# Altium schematic sheet 01_NRF52.SchDoc(01_NRF52)
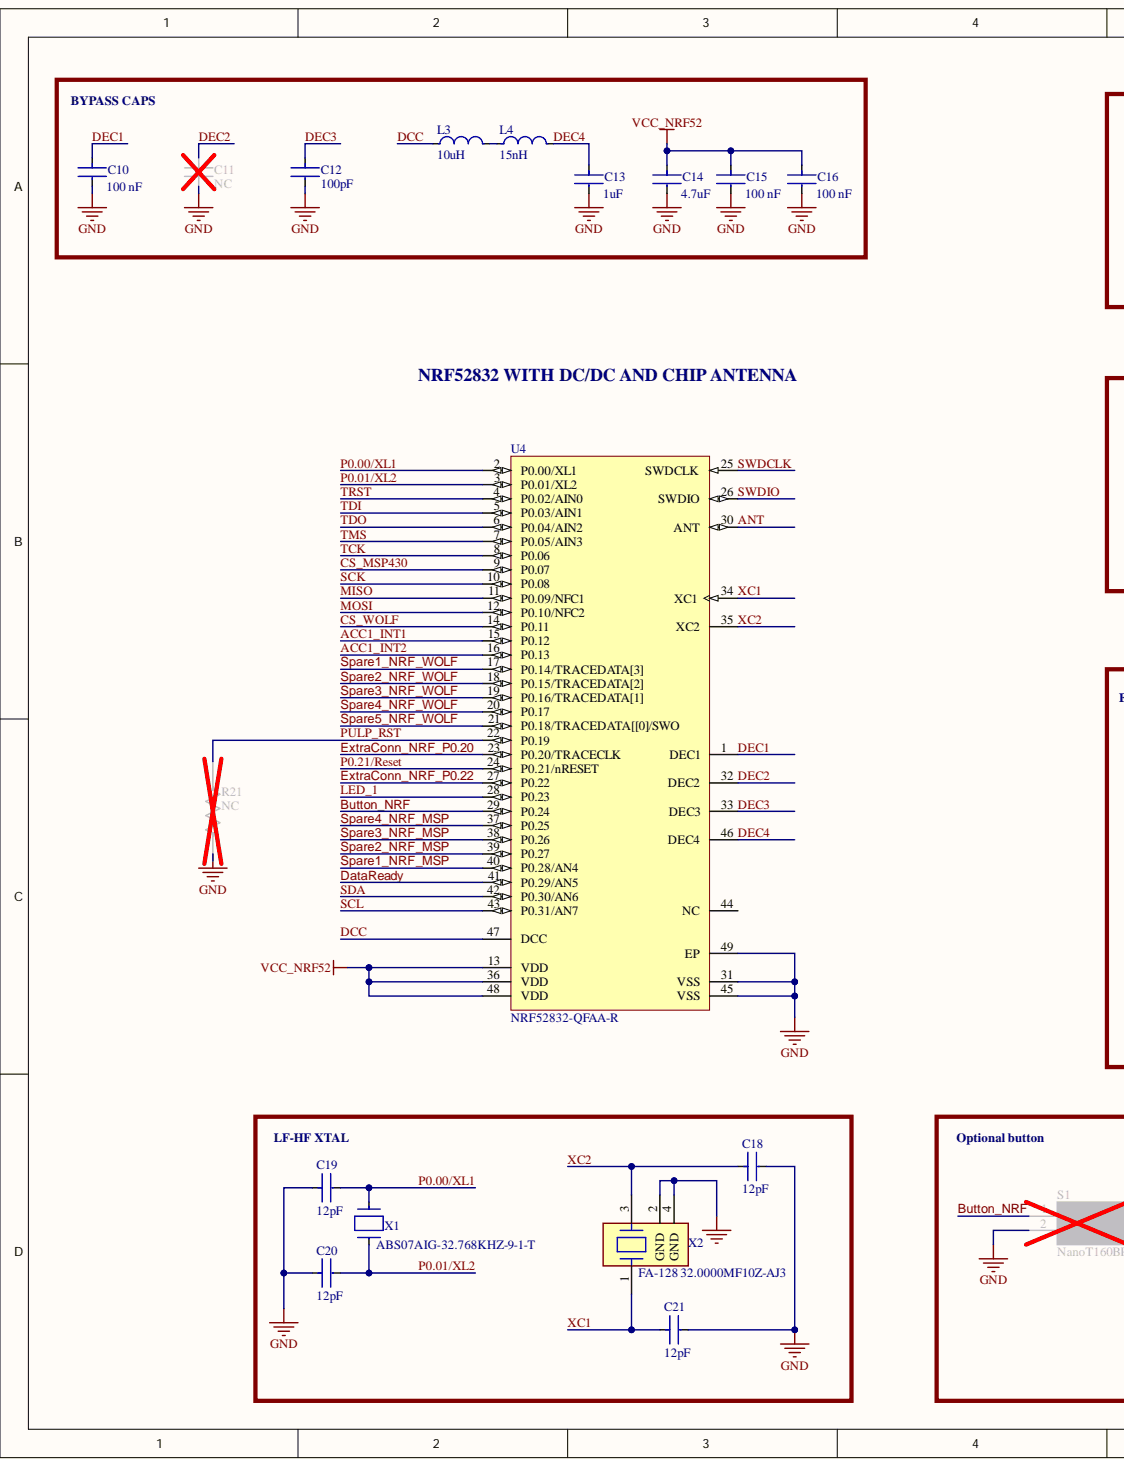
[diagram p0: 01_NRF52.SchDoc, left]
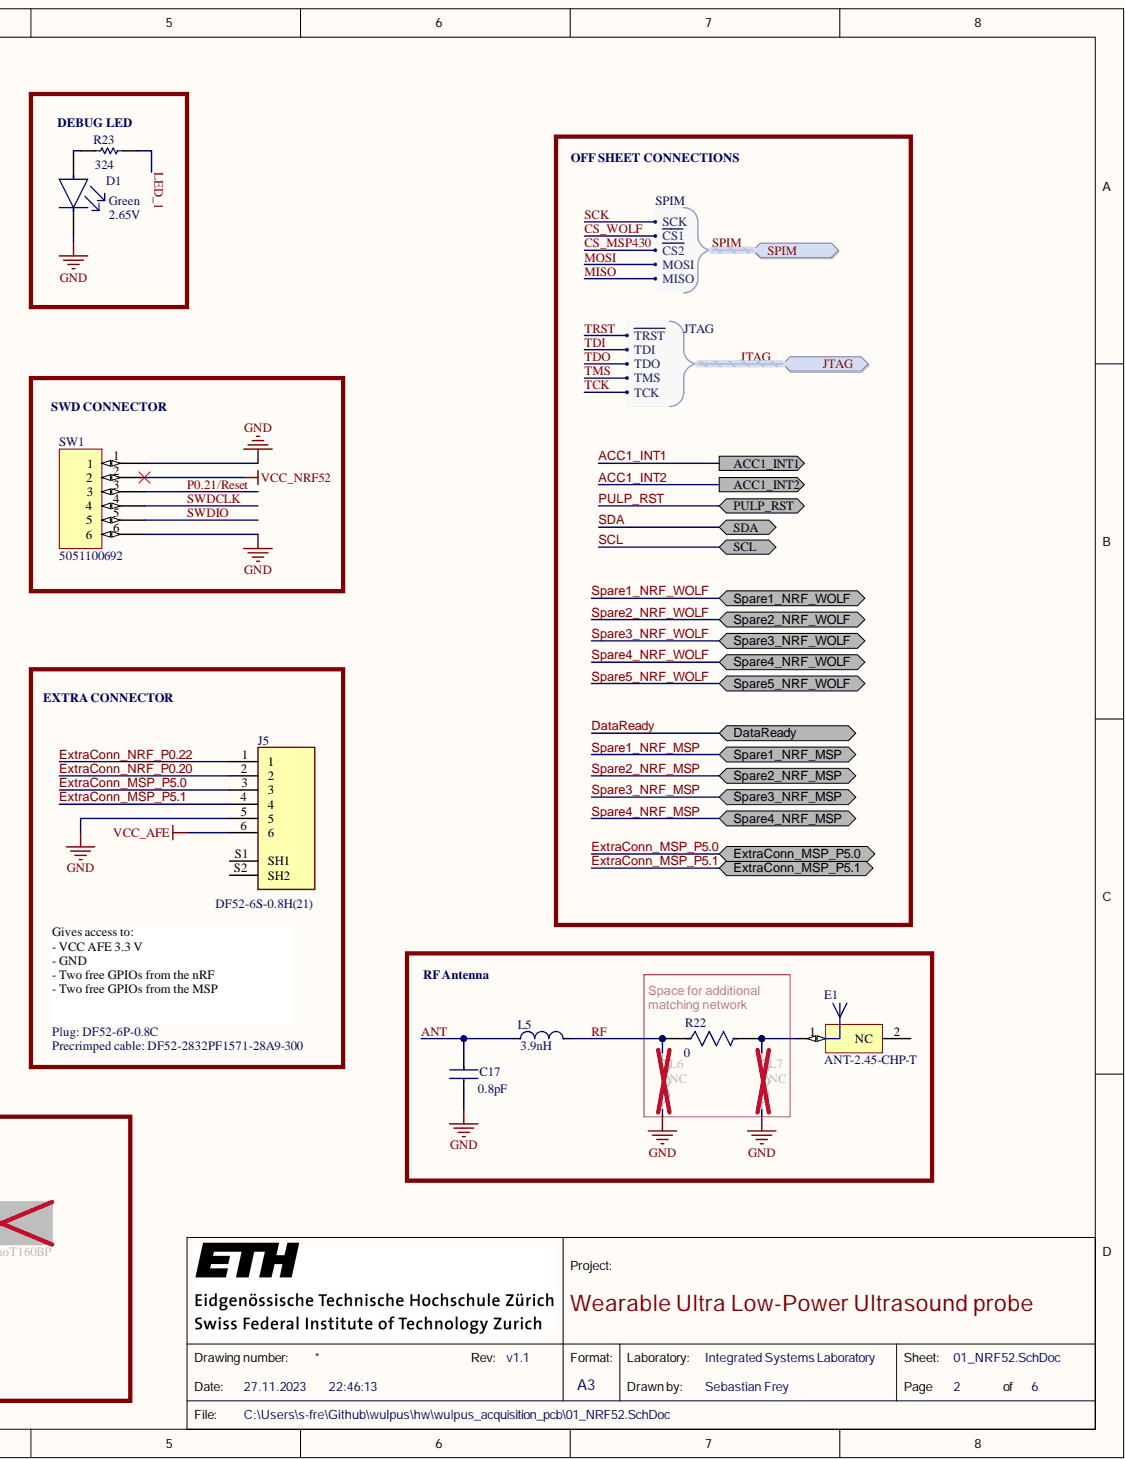
[diagram p1: 01_NRF52.SchDoc, right]

## Parts
C10  value 100 nF  Capacitance: 100 nF  [FP-CC0402-MFG]  (hidden comment: CC0402KRX7R7BB104)
C11  Capacitance: NC  [FP-04025A101JAT2A-MFG]  (hidden comment: not mounted)
C12  Capacitance: 100pF  [FP-04025A101JAT2A-MFG]  (hidden comment: 04025A101JAT2A)
C13  Capacitance: 1uF  [FP-C1608-080-0_1-IPC_B]  (hidden comment: C1608X7R1C105K080AC)
C14  Capacitance: 4.7uF  [FP-0603-L_1_6_0_15-W_0_8-IPC_A]  (hidden comment: EMK107ABJ475KA-T)
C15  value 100 nF  [FP-CC0402-MFG]  (hidden comment: CC0402KRX7R7BB104)
C16  value 100 nF  Capacitance: 100 nF  [FP-CC0402-MFG]  (hidden comment: CC0402KRX7R7BB104)
C17  Capacitance: 0.8pF  [FP-GJM155-0_05-IPC_A]  (hidden comment: GJM1555C1HR80WB01D)
C18  Capacitance: 12pF  [FP-GJM155-0_05-IPC_A]  (hidden comment: GJM1555C1H120GB01D)
C19  Capacitance: 12pF  [FP-GJM155-0_05-IPC_A]  (hidden comment: GJM1555C1H120GB01D)
C20  Capacitance: 12pF  [FP-GJM155-0_05-IPC_A]  (hidden comment: GJM1555C1H120GB01D)
C21  Capacitance: 12pF  [FP-GJM155-0_05-IPC_A]  (hidden comment: GJM1555C1H120GB01D)
D1  Color: Green  Forward Voltage: 2.65V  [FP-APHD1608LZGCK-MFG]  (hidden comment: APHD1608LZGCK)
E1  ANT-2.45-CHP-T  [ANT-2.4-CHP]
J5  DF52-6S-0.8H(21)  [HIROSE_DF52-6S-0.8H(21)]
L3  Inductance: 10uH  [L0603B100KDWFT]  (hidden comment: L0603B100KDWFT)
L4  Inductance: 15nH  [FP-LQW15AN_00-W0_6-0_1-MFG]  (hidden comment: LQW15AN15NJ00D)
L5  Inductance: 3.9nH  [INDC1005X45N]  (hidden comment: LQP15MN3N9B02D)
L6  Inductance: NC  [INDC1005X45N]
L7  Inductance: NC  [INDC1005X45N]
R21  Resistance: NC  [FP-ERJ2RK-IPC_C]
R22  CR0402-J/-000GLF  [FP-CR0402-IPC_B]  (value not drawn)
R23  CPF0402B324RE1  [RESC1005X35X20ML05T10]  (value not drawn)
S1  NanoT160BP  [NanoT160BP]
SW1  5051100692  [MOLEX_5051100692]
U4  NRF52832-QFAA-R  [NRSC-QF-48_M]
X1  ABS07AIG-32_768KHZ-9-1-T  Part Nr: ABS07AIG-32.768KHZ-9-1-T  [Crys_Abracon_ABS07AIG-32_768KHZ-9-1-T_eec_MFG]
X2  FA-128 32.0000MF10Z-AJ3  [Crys_Seiko_Epson_Corporation_FA-128_32.0000MF10Z-AJ3_eec_0]

## Ports
ACC1_INT1, ACC1_INT2, DataReady, ExtraConn_MSP_P5.0, ExtraConn_MSP_P5.1, JTAG, JTAG.TCK, JTAG.TDI, JTAG.TDO, JTAG.TMS, JTAG.~{TRST}, PULP_RST, SCL, SDA, Spare1_NRF_MSP, Spare1_NRF_WOLF, Spare2_NRF_MSP, Spare2_NRF_WOLF, Spare3_NRF_MSP, Spare3_NRF_WOLF, Spare4_NRF_MSP, Spare4_NRF_WOLF, Spare5_NRF_WOLF, SPIM, SPIM.MISO, SPIM.MOSI, SPIM.SCK, SPIM.~{CS1}, SPIM.~{CS2}

## Nets
GND: C10.2 C11.1 C12.1 C13.1 C14.2 C15.2 C16.2 C17.2 C18.2 C19.1 C20.1 C21.2 D1.1 J5.5 L6.2 L7.2 R21.2 S1.2 SW1.1 SW1.6 U4.31(VSS) U4.45(VSS) U4.49(EP) X2.2(GND) X2.4(GND)
VCC_AFE: J5.6
VCC_NRF52: C14.1 C15.1 C16.1 SW1.2 U4.13(VDD) U4.36(VDD) U4.48(VDD)
ACC1_INT1: U4.15(P0.12) ; port ACC1_INT1
ACC1_INT2: U4.16(P0.13) ; port ACC1_INT2
ANT: C17.1 L5.1 U4.30(ANT)
Button_NRF: S1.1 U4.29(P0.24)
CS_MSP430: U4.9(P0.07) ; port SPIM
CS_WOLF: U4.14(P0.11) ; port SPIM
DataReady: U4.41(P0.29/AN5) ; port DataReady
DCC: L3.1 U4.47(DCC)
DEC1: C10.1 U4.1(DEC1)
DEC2: C11.2 U4.32(DEC2)
DEC3: C12.2 U4.33(DEC3)
DEC4: C13.2 L4.2 U4.46(DEC4)
ExtraConn_MSP_P5.0: J5.3 ; port ExtraConn_MSP_P5.0
ExtraConn_MSP_P5.1: J5.4 ; port ExtraConn_MSP_P5.1
ExtraConn_NRF_P0.20: J5.2 U4.23(P0.20/TRACECLK)
ExtraConn_NRF_P0.22: J5.1 U4.27(P0.22)
JTAG.~{TRST}: U4.4(P0.02/AIN0) ; port JTAG
JTAG.TCK: U4.8(P0.06) ; port JTAG
JTAG.TDI: U4.5(P0.03/AIN1) ; port JTAG
JTAG.TDO: U4.6(P0.04/AIN2) ; port JTAG
JTAG.TMS: U4.7(P0.05/AIN3) ; port JTAG
LED_1: R23.1 U4.28(P0.23)
MISO: U4.11(P0.09/NFC1) ; port SPIM
MOSI: U4.12(P0.10/NFC2) ; port SPIM
P0.00/XL1: C19.2 U4.2(P0.00/XL1) X1.1
P0.01/XL2: C20.2 U4.3(P0.01/XL2) X1.2
P0.21/Reset: SW1.3 U4.24(P0.21/nRESET)
PULP_RST: R21.1 U4.22(P0.19) ; port PULP_RST
RF: L5.2 L6.1 R22.1
SCK: U4.10(P0.08) ; port SPIM
SCL: U4.43(P0.31/AN7) ; port SCL
SDA: U4.42(P0.30/AN6) ; port SDA
Spare1_NRF_MSP: U4.40(P0.28/AN4) ; port Spare1_NRF_MSP
Spare1_NRF_WOLF: U4.17(P0.14/TRACEDATA[3]) ; port Spare1_NRF_WOLF
Spare2_NRF_MSP: U4.39(P0.27) ; port Spare2_NRF_MSP
Spare2_NRF_WOLF: U4.18(P0.15/TRACEDATA[2]) ; port Spare2_NRF_WOLF
Spare3_NRF_MSP: U4.38(P0.26) ; port Spare3_NRF_MSP
Spare3_NRF_WOLF: U4.19(P0.16/TRACEDATA[1]) ; port Spare3_NRF_WOLF
Spare4_NRF_MSP: U4.37(P0.25) ; port Spare4_NRF_MSP
Spare4_NRF_WOLF: U4.20(P0.17) ; port Spare4_NRF_WOLF
Spare5_NRF_WOLF: U4.21(P0.18/TRACEDATA[[0]/SWO) ; port Spare5_NRF_WOLF
SWDCLK: SW1.4 U4.25(SWDCLK)
SWDIO: SW1.5 U4.26(SWDIO)
XC1: C21.1 U4.34(XC1) X2.1
XC2: C18.1 U4.35(XC2) X2.3
NetD1_2: D1.2 R23.2
NetE1_1: E1.1 L7.1 R22.2
NetL3_2: L3.2 L4.1

Not connected: E1 2; J5 S1(SH1) S2(SH2); U4 44(NC)

## Library data of the parts
C10 C16 = CMP-03422-000048-1: CAP CER 0.1UF 16V X7R 0402; Manufacturer: YAGEO; Automotive Grade: NA; Material: Ceramic; Temperature Coefficient: 30ppm/°C; Tolerance: 0.1; Automotive: No; Category: Cap; Component Kind: Standard; ComponentLink2Description: Datasheet; ComponentLink2URL: http://www.yageo.com/documents/recent/UPY-GP_NP0_16V-to-50V_16.pdf; Device Class L1: Passive Components; Device Class L2: Capacitors; Device Class L3: Ceramic Capacitors; Digikey Description: CAP CER 0.1UF 16V X7R 0402; Footprint Url: http://www.yageo.com/exep/pages/download/literatures/UPY-C_GEN_24.pdf; Height: 0.55mm; Ipc Land Pattern Name: CAPC10050X50; Lead Free: Yes; Library Name: Altium Content Vault; Manufacturer Part Number: CC0402KRX7R7BB104; Mouser Description: 0402 0.1 uF 16 V ±10 % Tolerance X7R SMT Multilayer Ceramic Capacitor; Octopart: https://octopart.com/cc0402krx7r7bb104-yageo-42244624; Package: CC0402; RoHS: TRUE; Temperature: -55°C to +125°C; Temperature Characteristic: X7R; Temperature Range High: +125°C; Temperature Range Low: -55°C; Voltage Rating: 16 V
C11 = CMP-2008-02568-2: Dielectric: C0G; Dissipation Factor: 2.5%; Insulation Resistance: 1GR; Temperature Coefficient: 30ppm/°C; Tolerance: 5%; Voltage: 50V; Case Code (Imperial): 0402; Case Code (Metric): 1005; Case/Package: 0402; Component Kind: Standard; Construction: Flat; Contact Plating: Tin; Depth: 0.5mm; Description: not mounted; Height: 0.56mm; Lead Free: Lead Free; Length: 1mm; Library Name: Altium Content Vault; Max Operating Temperature: 125°C; Min Operating Temperature: -55°C; Mount: Surface Mount; Package Quantity: 1; Packaging: Tape and Reel; Radiation Hardening: No; REACH SVHC: No SVHC; RoHS Compliant: Yes; Series: -; Termination: SMD/SMT; Thickness: 0.022inch; Voltage Rating: 50V; Voltage Rating (DC): 50V; Width: 0.02inch
C12 = CMP-2008-02568-2: CAP CER 100PF 50V C0G/NP0 0402; Dielectric: C0G; Dissipation Factor: 2.5%; Insulation Resistance: 1GR; Temperature Coefficient: 30ppm/°C; Tolerance: 5%; Case Code (Imperial): 0402; Case Code (Metric): 1005; Case/Package: 0402; Component Kind: Standard; Construction: Flat; Contact Plating: Tin; Depth: 0.5mm; Height: 0.56mm; Lead Free: Lead Free; Length: 1mm; Library Name: Altium Content Vault; Max Operating Temperature: 125°C; Min Operating Temperature: -55°C; Mount: Surface Mount; Package Quantity: 1; Packaging: Tape and Reel; Radiation Hardening: No; REACH SVHC: No SVHC; RoHS Compliant: Yes; Series: -; Termination: SMD/SMT; Thickness: 0.022inch; Voltage: 50V; Voltage Rating: 50V; Voltage Rating (DC): 50V; Width: 0.02inch
C13 = CMP-08246-002727-1: Multilayer Ceramic Capacitors 1μF ±10% 16V X7R SMD 0603; Case Code (Imperial): 0603; Case Code (Metric): 1608; Case/Package: 0603; Component Kind: Standard; Construction: Flat; Depth: 0.8mm; Dielectric: X7R; Dielectric Material: Ceramic; Height: 0.8mm; Lead Free: Lead Free; Length: 1.6mm; Library Name: Altium Content Vault; Max Operating Temperature: 125°C; Min Operating Temperature: -55°C; Mount: Surface Mount; Package Quantity: 1; Packaging: Tape and Reel; Radiation Hardening: No; REACH SVHC: No SVHC; Resistor Type: Ceramic; RoHS Compliant: Yes; Series: C; Termination: SMD/SMT; Thickness: 0.037inch; Tolerance: 10%; Voltage: 16V; Voltage Rating: 16V; Voltage Rating (DC): 16V; Weight: 7.1E-05oz; Width: 0.031inch
C14 = CMP-2000-06225-3: None; Dielectric: X5R; Tolerance: 10%; Voltage Rating: 16V; Case Code (Imperial): 0603; Case Code (Metric): 1608; Case/Package: 0603; Component Kind: Standard; Construction: Flat; Height: 0.8mm; Length: 1.6mm; Library Name: Altium Content Vault; Max Operating Temperature: 85°C; Min Operating Temperature: -55°C; Mount: Surface Mount; Number of Pins: 2; Package Quantity: 1; Packaging: Tape and Reel; REACH SVHC: No SVHC; Resistor Type: Ceramic; RoHS Compliant: Yes; Series: M; Simulation: EMK107ABJ475_A-T; Termination: SMD/SMT; Thickness: 0.035inch; Voltage Rating (DC): 16V; Width: 0.8mm
C15 = CMP-03422-000048-1: CAP CER 0.1UF 16V X7R 0402; Manufacturer: YAGEO; Automotive Grade: NA; Capacitance: 100 nF; Material: Ceramic; Temperature Coefficient: 30ppm/°C; Tolerance: 0.1; Value: 100 nF; Automotive: No; Category: Cap; Component Kind: Standard; ComponentLink2Description: Datasheet; ComponentLink2URL: http://www.yageo.com/documents/recent/UPY-GP_NP0_16V-to-50V_16.pdf; Device Class L1: Passive Components; Device Class L2: Capacitors; Device Class L3: Ceramic Capacitors; Digikey Description: CAP CER 0.1UF 16V X7R 0402; Footprint Url: http://www.yageo.com/exep/pages/download/literatures/UPY-C_GEN_24.pdf; Height: 0.55mm; Ipc Land Pattern Name: CAPC10050X50; Lead Free: Yes; Library Name: Altium Content Vault; Manufacturer Part Number: CC0402KRX7R7BB104; Mouser Description: 0402 0.1 uF 16 V ±10 % Tolerance X7R SMT Multilayer Ceramic Capacitor; Octopart: https://octopart.com/cc0402krx7r7bb104-yageo-42244624; Package: CC0402; RoHS: TRUE; Temperature: -55°C to +125°C; Temperature Characteristic: X7R; Temperature Range High: +125°C; Temperature Range Low: -55°C; Voltage Rating: 16 V
C17 = CMP-2008-03761-2: Multi-Layer Ceramic Capacitor 0.8pF C0G  ±0.05pF 0402 Paper T/R; Voltage Rating: 50V; Case Code (Imperial): 0402; Case Code (Metric): 1005; Case/Package: 0402; Component Kind: Standard; Depth: 0.5mm; Dielectric: C0G; Height: 0.5mm; Length: 1mm; Library Name: Altium Content Vault; Max Operating Temperature: 125°C; Min Operating Temperature: -55°C; Mount: Surface Mount; Package Quantity: 10000; Packaging: Tape and Reel; Radiation Hardening: No; Termination: SMD/SMT; Thickness: 0.022inch; Voltage Rating (DC): 50V; Width: 0.02inch
C18-C21 = CMP-2008-03799-2: Multi-Layer Ceramic Capacitor 12pF C0G  ±2% 0402 Paper T/R; Voltage Rating: 50V; Case Code (Imperial): 0402; Case Code (Metric): 1005; Case/Package: 0402; Component Kind: Standard; Depth: 0.5mm; Dielectric: C0G; Height: 0.5mm; Lead Free: Lead Free; Length: 1mm; Library Name: Altium Content Vault; Max Operating Temperature: 125°C; Min Operating Temperature: -55°C; Mount: Surface Mount; Package Quantity: 10000; Packaging: Tape and Reel; Radiation Hardening: No; Termination: SMD/SMT; Thickness: 0.022inch; Tolerance: 2%; Voltage Rating (DC): 50V; Width: 0.5mm
D1 = CMP-44529-000045-1: LED GREEN CLEAR 1608 SMD; Dominant Wavelength: 525nm; Lens Color: Colorless; Lens Size: 0.7mm; Lens Style: Round; Luminous Intensity: 180mcd; Test Current: 2mA; Viewing Angle: 100°; Case Code (Imperial): 0603; Case Code (Metric): 1608; Case/Package: 0603; Component Kind: Standard; Height: 0.75mm; Length: 1.6mm; Lens Transparency: Clear; Library Name: Altium Content Vault; Mount: Surface Mount; Packaging: Tape and Reel; Width: 0.8mm
E1 = CMP-0172-00025-1: 1/4-wave Monopole Ultra-Compact Chip Antenna, CHP Series, 2.4GHz, Tape and Reel; Note1: No ground planes or traces under the antenna; Note2: Pin 1 is feed terminal, Pin 2 is for physical support only.; PCB_Layout: Complies with manufacturer's recommendation.; Component Kind: Standard; DatasheetVersion: Jan-2009; Library Name: Altium Content Vault; PackageDescription: SMD Ceramic Antenna, CHP Series, 2.45MHz, 2-Leads, 6.5 x 2.2 x 1.0 mm Body; PackageReference: ANT-2.4-CHP; PackageVersion: Jan-2009
J5 = DF52-6S-0.8H(21): 6 Position Socket Connector Surface Mount, Right Angle; MF: Hirose; MP: DF52-6S-0.8H_21_; Availability: Unavailable; Component Kind: Standard; Library Name: Schlib1.SchLib; Package: None; Price: None; SnapEDA_Link: https://www.snapeda.com/parts/DF52-6S-0.8H%2821%29/Hirose%20Electric%20Co%20Ltd/view-part/624923/?ref=snap
L3 = L0603B100KDWFT: Inductor RF Chip Wirewound 10uH 10% 2.52MHz 0.38A 0.36Ohm DCR 0603 T/R; Manufacturer: KEMET; DC Current: 380mA; DC Resistance (DCR): 360mR; Max DC Current: 115mA; Self Resonant Frequency: 32MHz; Shielding: Shielded; Case/Package: 0603; Category: Fixed Inductors; Component Kind: Standard; ComponentLink1Description: http://www.mouser.com/ds/2/212/F3115-1104303.pdf?src-supplier=Mouser; ComponentLink1URL: http://www.mouser.com/ds/2/212/F3115-1104303.pdf?src-supplier=Mouser; ComponentLink2Description: http://components.arrow.com/part/dataview/0323_00380344?src-supplier=Arrow+Europe; ComponentLink2URL: http://components.arrow.com/part/dataview/0323_00380344?src-supplier=Arrow+Europe; Depth: 1mm; Height: 0.8mm; Length: 1.6mm; Library Name: Schlib1.SchLib; Manufacturer Part Number: L0603B100KDWFT; Max Operating Temperature: 105°C; Military Standard: Not; Min Operating Temperature: -25°C; Package Quantity: 3000; Packaging: Tape and Reel; Pricing 1: 5=0.45200, 50=0.25500, 250=0.24300, 500=0.20900, 1500=0.18400, 3000=0.15700, 15000=0.13700 (CHF); Resistor Type: Ceramic; RoHS: Yes; RoHS Compliant: Yes; Series: L-DWF; Stock 1: 1725; Supplier 1: Farnell; Supplier Part Number 1: 3638979; Termination: SMD/SMT; Test Frequency: 2.52MHz; Tolerance: 10%; Width: 0.8mm
L4 = CMP-2000-06595-2: Wire Wound RF Inductor 15nH ±5% 460mA 0.16Ω 0402 (1005); Core Material: Air; DC Resistance (DCR): 160mR; Q Factor: 30; Self Resonant Frequency: 5GHz; Shielding: Unshielded; Test Frequency: 100MHz; Case Code (Imperial): 0402; Case Code (Metric): 1005; Case/Package: 0402; Component Kind: Standard; Current Rating: 460mA; DC Current: 460mA; Depth: 0.7mm; Height: 0.5mm; Lead Free: Lead Free; Length: 1mm; Library Name: Altium Content Vault; Max DC Current: 460mA; Max Operating Temperature: 125°C; Military Standard: Not; Min Operating Temperature: -55°C; Mount: Surface Mount; Package Quantity: 10000; Packaging: Tape and Reel; Radiation Hardening: No; Resistor Type: Wirewound; Termination: SMD/SMT; Tolerance: 5%; Weight: 2.8E-05oz; Width: 0.02inch
L5 = LQP15MN3N9B02D: Inductor RF Chip Thin Film 3.9nH 0.1nH 500MHz 13Q-Factor 170mA 500mOhm DCR 0402 Paper T/R; Manufacturer: Murata; Core Material: Non-Magnetic; DC Resistance (DCR): 500mR; Q Factor: 13; Self Resonant Frequency: 6GHz; Shielding: Unshielded; Case Code (Imperial): 0402; Case Code (Metric): 1005; Case/Package: 0402; Category: Fixed Inductors; Component Kind: Standard; ComponentLink1Description: https://datasheet.ciiva.com/27054/1865137-27054329.pdf?src-supplier=Jameco; ComponentLink1URL: https://datasheet.ciiva.com/27054/1865137-27054329.pdf?src-supplier=Jameco; ComponentLink2Description: https://datasheet.ciiva.com/11799/0900766b80f155d9-11799807.pdf?src-supplier=RSComponents; ComponentLink2URL: https://datasheet.ciiva.com/11799/0900766b80f155d9-11799807.pdf?src-supplier=RSComponents; ComponentLink3Description: https://datasheet.ciiva.com/8510/l0075s0084lqp15m-8510329.pdf?src-supplier=Avnet; ComponentLink3URL: https://datasheet.ciiva.com/8510/l0075s0084lqp15m-8510329.pdf?src-supplier=Avnet; ComponentLink4Description: https://datasheet.ciiva.com/4976/product-4976732.pdf?src-supplier=Mouser; ComponentLink4URL: https://datasheet.ciiva.com/4976/product-4976732.pdf?src-supplier=Mouser; ComponentLink5Description: https://datasheet.ciiva.com/1039/l0075s0084lqp15m-1039837.pdf?src-supplier=Digi-Key; ComponentLink5URL: https://datasheet.ciiva.com/1039/l0075s0084lqp15m-1039837.pdf?src-supplier=Digi-Key; ComponentLink6Description: https://datasheet.ciiva.com/1039/l0075s0084lqp15m-1039838.pdf?src-supplier=Digi-Key; ComponentLink6URL: https://datasheet.ciiva.com/1039/l0075s0084lqp15m-1039838.pdf?src-supplier=Digi-Key; ComponentLink7Description: https://datasheet.ciiva.com/1039/l0075s0084lqp15m-1039839.pdf?src-supplier=Digi-Key; ComponentLink7URL: https://datasheet.ciiva.com/1039/l0075s0084lqp15m-1039839.pdf?src-supplier=Digi-Key; ComponentLink8Description: https://datasheet.ciiva.com/16362/l0075s0084lqp15m-16362181.pdf?src-supplier=Future+Electronics; ComponentLink8URL: https://datasheet.ciiva.com/16362/l0075s0084lqp15m-16362181.pdf?src-supplier=Future+Electronics; ComponentLink9Description: https://datasheet.ciiva.com/18230/getdatasheetpartid-153200-18230711.pdf?src-supplier=Verical; ComponentLink9URL: https://datasheet.ciiva.com/18230/getdatasheetpartid-153200-18230711.pdf?src-supplier=Verical; ComponentLink10Description: https://datasheet.ciiva.com/8510/l0075s0084lqp15m-8510975.pdf?src-supplier=Avnet; ComponentLink10URL: https://datasheet.ciiva.com/8510/l0075s0084lqp15m-8510975.pdf?src-supplier=Avnet; Current Rating: 170mA; DC Current: 170mA; Depth: 0.6mm; Height: 0.35mm; Height - Seated (Max): 0.018inch; Lead Free: Lead Free; Length: 1.1mm; Library Name: Schlib1.SchLib; Manufacturer Part Number: LQP15MN3N9B02D; Max DC Current: 170mA; Max Operating Temperature: 85°C; Military Standard: Not; Min Operating Temperature: -40°C; Mount: Surface Mount; Package Quantity: 10000; Packaging: Tape and Reel; Pricing 1: 1=0.18000, 10=0.15800, 25=0.14040, 50=0.11920, 100=0.10520, 250=0.09644, 500=0.08768, 1000=0.07365, 2500=0.06313 (USD); Radiation Hardening: No; Rated Current-Max: 170mA; Resistor Type: Thin Film; RoHS: Yes; RoHS Compliant: Yes; Series: LQP15M; Stock 1: 22125; Supplier 1: Digi-Key; Supplier Part Number 1: 490-1131-1-ND; Technology: THIN FILM; Termination: SMD/SMT; Test Frequency: 500MHz; Width: 0.5mm
L6 L7 = LQP15MN3N9B02D: not mounted; Manufacturer: Murata; Core Material: Non-Magnetic; Current Rating: 170mA; DC Resistance (DCR): 500mR; Q Factor: 13; Self Resonant Frequency: 6GHz; Shielding: Unshielded; Test Frequency: 500MHz; Case Code (Imperial): 0402; Case Code (Metric): 1005; Case/Package: 0402; Category: Fixed Inductors; Component Kind: Standard; ComponentLink1Description: https://datasheet.ciiva.com/27054/1865137-27054329.pdf?src-supplier=Jameco; ComponentLink1URL: https://datasheet.ciiva.com/27054/1865137-27054329.pdf?src-supplier=Jameco; ComponentLink2Description: https://datasheet.ciiva.com/11799/0900766b80f155d9-11799807.pdf?src-supplier=RSComponents; ComponentLink2URL: https://datasheet.ciiva.com/11799/0900766b80f155d9-11799807.pdf?src-supplier=RSComponents; ComponentLink3Description: https://datasheet.ciiva.com/8510/l0075s0084lqp15m-8510329.pdf?src-supplier=Avnet; ComponentLink3URL: https://datasheet.ciiva.com/8510/l0075s0084lqp15m-8510329.pdf?src-supplier=Avnet; ComponentLink4Description: https://datasheet.ciiva.com/4976/product-4976732.pdf?src-supplier=Mouser; ComponentLink4URL: https://datasheet.ciiva.com/4976/product-4976732.pdf?src-supplier=Mouser; ComponentLink5Description: https://datasheet.ciiva.com/1039/l0075s0084lqp15m-1039837.pdf?src-supplier=Digi-Key; ComponentLink5URL: https://datasheet.ciiva.com/1039/l0075s0084lqp15m-1039837.pdf?src-supplier=Digi-Key; ComponentLink6Description: https://datasheet.ciiva.com/1039/l0075s0084lqp15m-1039838.pdf?src-supplier=Digi-Key; ComponentLink6URL: https://datasheet.ciiva.com/1039/l0075s0084lqp15m-1039838.pdf?src-supplier=Digi-Key; ComponentLink7Description: https://datasheet.ciiva.com/1039/l0075s0084lqp15m-1039839.pdf?src-supplier=Digi-Key; ComponentLink7URL: https://datasheet.ciiva.com/1039/l0075s0084lqp15m-1039839.pdf?src-supplier=Digi-Key; ComponentLink8Description: https://datasheet.ciiva.com/16362/l0075s0084lqp15m-16362181.pdf?src-supplier=Future+Electronics; ComponentLink8URL: https://datasheet.ciiva.com/16362/l0075s0084lqp15m-16362181.pdf?src-supplier=Future+Electronics; ComponentLink9Description: https://datasheet.ciiva.com/18230/getdatasheetpartid-153200-18230711.pdf?src-supplier=Verical; ComponentLink9URL: https://datasheet.ciiva.com/18230/getdatasheetpartid-153200-18230711.pdf?src-supplier=Verical; ComponentLink10Description: https://datasheet.ciiva.com/8510/l0075s0084lqp15m-8510975.pdf?src-supplier=Avnet; ComponentLink10URL: https://datasheet.ciiva.com/8510/l0075s0084lqp15m-8510975.pdf?src-supplier=Avnet; DC Current: 170mA; Depth: 0.6mm; Height: 0.35mm; Height - Seated (Max): 0.018inch; Lead Free: Lead Free; Length: 1.1mm; Library Name: Schlib1.SchLib; Manufacturer Part Number: LQP15MN3N9B02D; Max DC Current: 170mA; Max Operating Temperature: 85°C; Military Standard: Not; Min Operating Temperature: -40°C; Mount: Surface Mount; Package Quantity: 10000; Packaging: Tape and Reel; Pricing 1: 1=0.18000, 10=0.15800, 25=0.14040, 50=0.11920, 100=0.10520, 250=0.09644, 500=0.08768, 1000=0.07365, 2500=0.06313 (USD); Radiation Hardening: No; Rated Current-Max: 170mA; Resistor Type: Thin Film; RoHS: Yes; RoHS Compliant: Yes; Series: LQP15M; Stock 1: 22125; Supplier 1: Digi-Key; Supplier Part Number 1: 490-1131-1-ND; Technology: THIN FILM; Termination: SMD/SMT; Width: 0.5mm
R21 = CMP-2002-00608-4: not mounted; Max Power Dissipation: 100mW; Temperature Coefficient: 100ppm/°C; Tolerance: 1%; Voltage Rating: 50V; Case Code (Imperial): 0402; Case Code (Metric): 1005; Case/Package: 0402; Component Kind: Standard; Composition: Thick Film; Contact Plating: Tin; Depth: 0.5mm; Features: Automotive AEC-Q200; Height: 0.35mm; Lead Free: Lead Free; Length: 1mm; Library Name: Altium Content Vault; Max Operating Temperature: 155°C; Military Standard: Not; Min Operating Temperature: -55°C; Mount: Surface Mount; Number of Pins: 2; Number of Terminations: 2; Package Quantity: 1; Packaging: Tape and Reel; Power Rating: 100mW; Radiation Hardening: No; REACH SVHC: No SVHC; Termination: SMD/SMT; Voltage Rating (DC): 50V; Width: 0.5mm
R22 = CMP-2002-05495-2: Thick Film Chip Resistors 0402 0Ω 0.0625W 5%; Max Power Dissipation: 63mW; Power Rating: 62.5mW; Resistance: 0; Temperature Coefficient: 500ppm/°C; Voltage Rating: 50V; Case Code (Imperial): 0402; Case Code (Metric): 1005; Case/Package: 0402; Component Kind: Standard; Composition: Thick Film; Contact Plating: Tin; Depth: 0.5mm; Height: 0.35mm; Length: 1mm; Library Name: Altium Content Vault; Max Operating Temperature: 125°C; Min Operating Temperature: -55°C; Mount: Surface Mount; Number of Pins: 2; Package Quantity: 10000; Packaging: Tape and Reel; Radiation Hardening: No; REACH SVHC: No SVHC; Termination: SMD/SMT; Thickness: 356um; Tolerance: 5%; Voltage Rating (DC): 50V; Width: 0.5mm
R23 = CMP-2002-03257-1: Manufacturer: TE Connectivity / AMP; Resistance: 324; Component Kind: Standard; Library Name: Altium Content Vault; Part Number: CPF0402B324RE1
S1 = NanoT160BP: Switch; Manufacturer_Name: LITTELFUSE; Component Kind: Standard; Datasheet Link: https://www.ckswitches.com/media/2866/nanot.pdf; Height: 1mm; Library Name: Schlib1.SchLib; Manufacturer_Part_Number: NanoT160BP
SW1 = MOLEX_5051100692: Component Kind: Standard; Library Name: Schlib1.SchLib
U4 = CMP-2100-03661-1: Multiprotocol Bluetooth Smart, ANT/ANT+ and Proprietary System-on-Chip, 2.4 GHz, 256 KB FLASH, 1.7 to 3.6 V, -40 to 85 degC, 48-Pin QFN, RoHS, Tape and Reel; Manufacturer: Nordic Semiconductor; Frequency: 2.4GHz; Component Kind: Standard; Datasheet URL: http://infocenter.nordicsemi.com/pdf/nRF52832_PS_v1.0.pdf; Datasheet Version: Rev. 1.0, 02/2016; Lead Free: LeadFree; Library Name: Altium Content Vault; Manufacturer URL: http://www.nordicsemi.com/eng/Products/; Max Frequency: 2.4GHz; Max Operating Temperature: 85degC; Min Operating Temperature: -40degC; Mount: SurfaceMount; Package Description: 48-Pin Quad Flat No-lead Package, Body 6 x 6 mm, Pitch 0.4 mm, Thermal Pad 4.6 x 4.6 mm; Package Reference: QF-48; Packaging: TapeandReel; RoHSCompliant: true
X1 = Crys_Abracon_ABS07AIG-32_768KHZ-9-1-T_eec: Crystal; Component Kind: Standard; Library Name: Schlib1.SchLib
X2 = Crys_Seiko_Epson_Corporation_FA-128_32.0000MF10Z-AJ3_eec: Crystal; Component Kind: Standard; Library Name: Schlib1.SchLib; Part Nr: FA-128 32.0000MF10Z-AJ3
